# Altium schematic sheet System.SchDoc
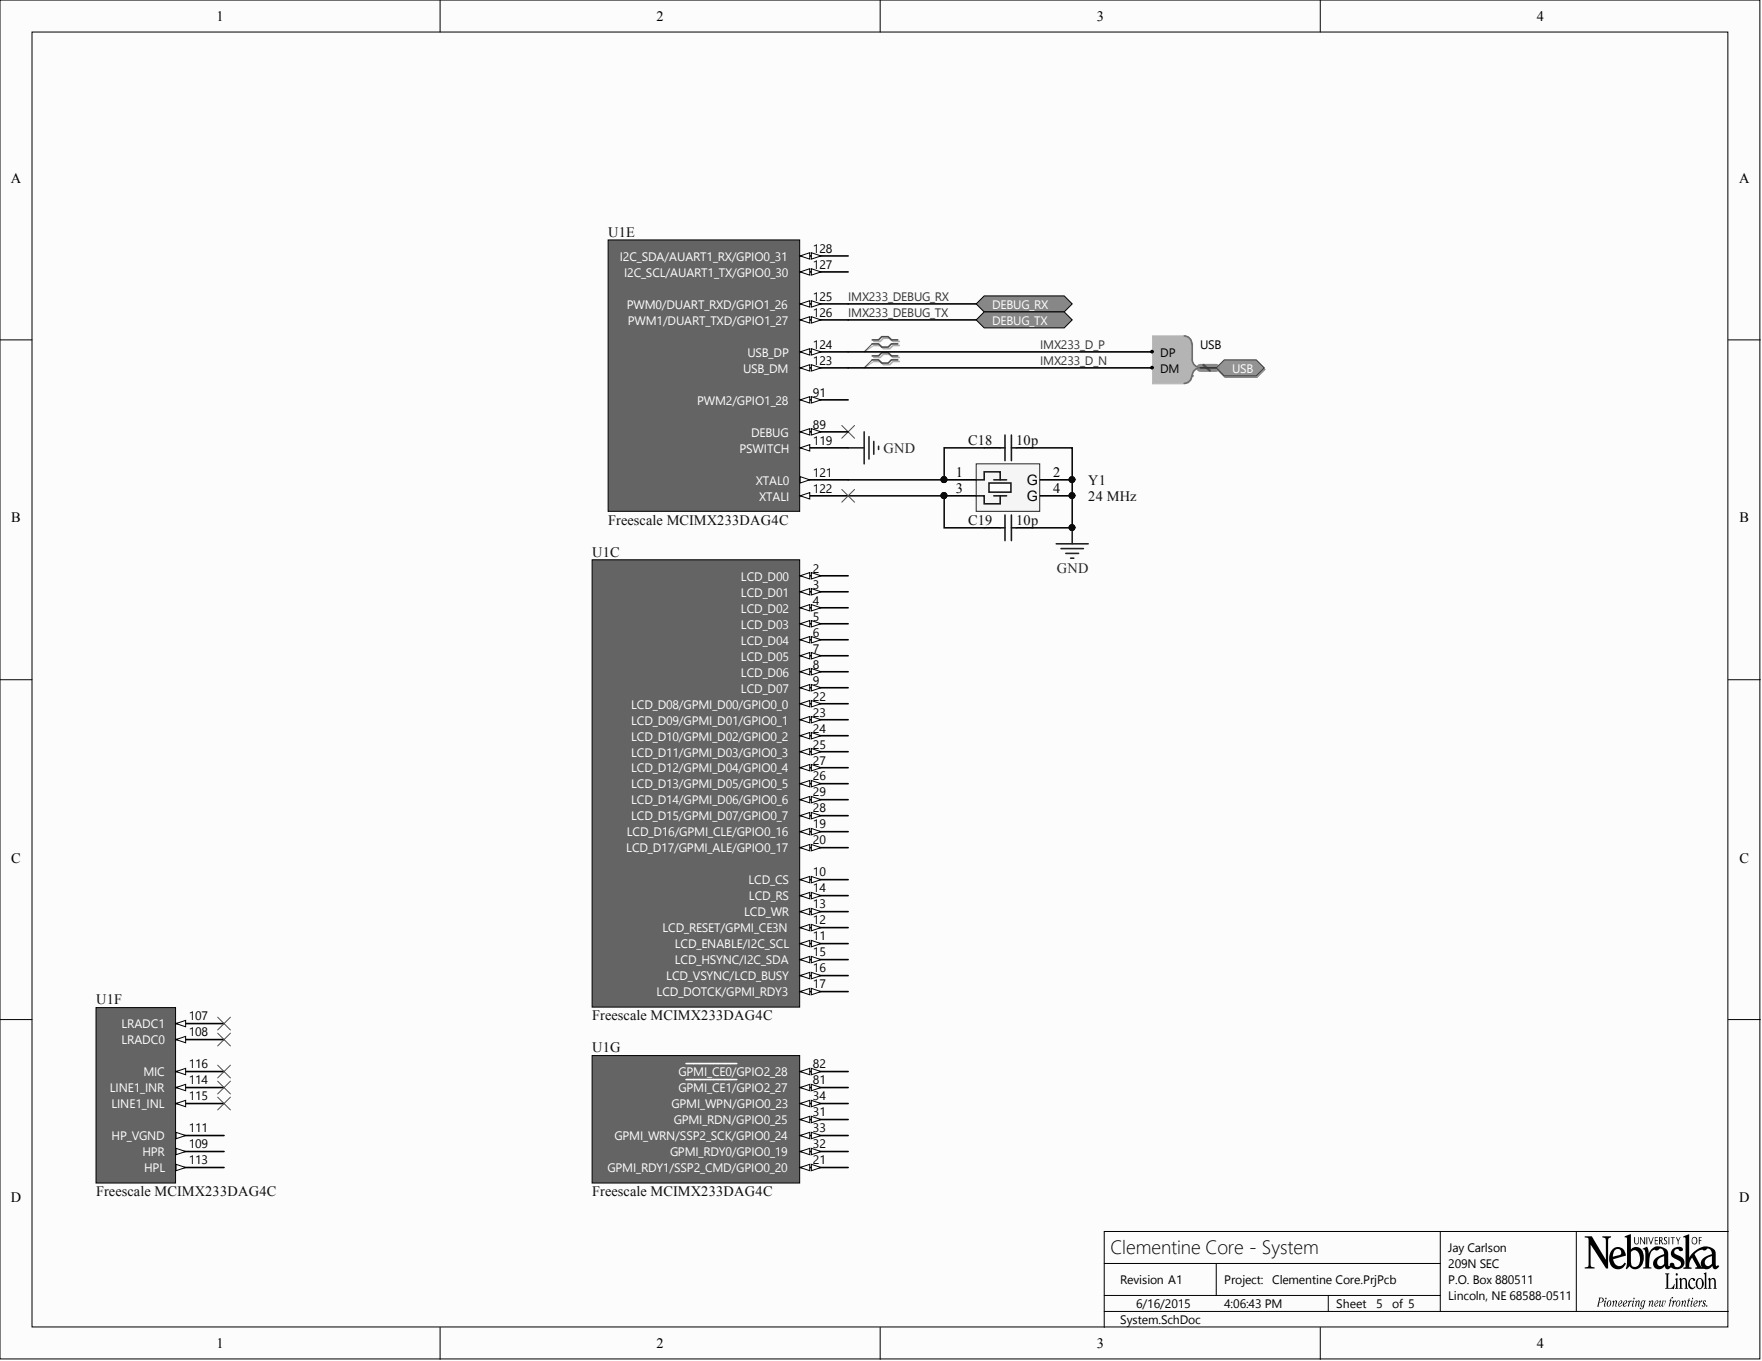
[diagram p0: System.SchDoc]

## Parts
C18  10p  [CAPC1005X56X25NL10T15]
C19  10p  [CAPC1005X56X25NL10T15]
U1  Freescale MCIMX233DAG4C  Manufacturer Part Number: MCIMX233DAG4C  [PCB-1234233-1]  (parts C,E,F,G)
Y1  24 MHz  [TXC-7B]

## Ports
DEBUG_RX, DEBUG_TX, USB, USB.DM, USB.DP

## Nets
GND: C18.2 C19.2 U1.119(PSWITCH) Y1.2(G) Y1.4(G)
IMX233_D_N: U1.123(USB_DM) ; port USB
IMX233_D_P: U1.124(USB_DP) ; port USB
IMX233_DEBUG_RX: U1.125(PWM0/DUART_RXD/GPIO1_26) ; port DEBUG_RX
IMX233_DEBUG_TX: U1.126(PWM1/DUART_TXD/GPIO1_27) ; port DEBUG_TX
NetC18_1: C18.1 U1.121(XTAL0) Y1.1
NetC19_1: C19.1 U1.122(XTALI) Y1.3

Not connected: U1 2(LCD_D00) 3(LCD_D01) 4(LCD_D02) 5(LCD_D03) 6(LCD_D04) 7(LCD_D05) 8(LCD_D06) 9(LCD_D07) 10(LCD_CS) 11(LCD_ENABLE/I2C_SCL) 12(LCD_RESET/GPMI_CE3N) 13(LCD_WR) 14(LCD_RS) 15(LCD_HSYNC/I2C_SDA) 16(LCD_VSYNC/LCD_BUSY) 17(LCD_DOTCK/GPMI_RDY3) 19(LCD_D16/GPMI_CLE/GPIO0_16) 20(LCD_D17/GPMI_ALE/GPIO0_17) 21(GPMI_RDY1/SSP2_CMD/GPIO0_20) 22(LCD_D08/GPMI_D00/GPIO0_0) 23(LCD_D09/GPMI_D01/GPIO0_1) 24(LCD_D10/GPMI_D02/GPIO0_2) 25(LCD_D11/GPMI_D03/GPIO0_3) 26(LCD_D13/GPMI_D05/GPIO0_5) 27(LCD_D12/GPMI_D04/GPIO0_4) 28(LCD_D15/GPMI_D07/GPIO0_7) 29(LCD_D14/GPMI_D06/GPIO0_6) 31(GPMI_RDN/GPIO0_25) 32(GPMI_RDY0/GPIO0_19) 33(GPMI_WRN/SSP2_SCK/GPIO0_24) 34(GPMI_WPN/GPIO0_23) 81(GPMI_CE1/GPIO2_27) 82(GPMI_CE0/GPIO2_28) 89(DEBUG) 91(PWM2/GPIO1_28) 107(LRADC1) 108(LRADC0) 109(HPR) 111(HP_VGND) 113(HPL) 114(LINE1_INR) 115(LINE1_INL) 116(MIC) 127(I2C_SCL/AUART1_TX/GPIO0_30) 128(I2C_SDA/AUART1_RX/GPIO0_31)

## Library data of the parts
C18 C19 = CMP-126405-1: Cap Ceramic 10pF 50V C0G 0.25pF SMD 0402 125C Paper T/R; Manufacturer Part Number: 04025A100CAT2A; CiivaID: 126405; Dielectric: C0G/NP0; Capacitance: 10 pF; Case Size/Dimension: 0402; Ciiva URL: https://ciiva.com/part/04025a100cat2a-126405; Component Kind: Standard; Library Name: CommunityVault; Rated Voltage: 50 V; Tolerance (pF): ±0.25 pF
U1 = CMP-1234233-6: MPU 32BIT I.MX233 128LQFP; Processing Unit: Microprocessor; Rad Hardened: No; Screening Level: Commercial; CiivaID: 1234233; Ciiva URL: https://ciiva.com/part/mcimx233dag4c-1234233; Component Kind: Standard; Library Name: CommunityVault; Mounting: Surface Mount; Package Type: LQFP; Packaging: Tray; Pin Count: 128; Technology: 0.09um
Y1 = CMP-783459-1: CRYSTAL 24.000MHZ 18PF SMD; Manufacturer Part Number: 7B-24.000MAAJ-T; Catalog Drawings: 7B Series Top7B Series Bottom7B Series Side 17B Series Side 27B Series Circuit; CiivaID: 783459; Dynamic Catalog: 7B Series; ESR (Equivalent Series Resistance): 40 Ohm; Frequency: 24MHz; Frequency Stability: ±30ppm; Operating Mode: Fundamental; Other Names: 887-1110-1; Category: Crystals and Oscillators; Ciiva URL: https://ciiva.com/part/7B-24.000MAAJ-T-783459; Component Kind: Standard; Family: Crystals; Frequency Tolerance: ±30ppm; Height: 0.041" (1.05mm); Library Name: CommunityVault; Load Capacitance: 18pF; Mounting Type: Surface Mount; Operating Temperature: -20°C ~ 70°C; Package / Case: 4-SMD, No Lead (DFN, LCC); Packaging: Cut Tape (CT); Series: 7B; Size / Dimension: 0.197" L x 0.126" W (5.00mm x 3.20mm); Standard Package: 1; Type: MHz Crystal
